# Altium schematic sheet CW.SchDoc(CW) (continued)

## Library data of the parts
C1 = C0805YC474KAT2A: CAP, X7R, 470NF, 16V, 0805, FULL REEL; Manufacturer: Kyocera AVX; Dissipation Factor: 3.5%; Insulation Resistance: 100GR; Tolerance: 10%; Capacitance: 470nF; Case Code (Imperial): 0805; Case Code (Metric): 2012; Case/Package: 0805; Category: Ceramic Capacitors; Component Kind: Standard; ComponentLink1Description: https://datasheet.ciiva.com/19476/2011221-19476183.pdf?src-supplier=Jameco; ComponentLink1URL: https://datasheet.ciiva.com/19476/2011221-19476183.pdf?src-supplier=Jameco; ComponentLink2Description: https://datasheet.ciiva.com/11786/0900766b80ed4be2-11786321.pdf?src-supplier=RSComponents; ComponentLink2URL: https://datasheet.ciiva.com/11786/0900766b80ed4be2-11786321.pdf?src-supplier=RSComponents; ComponentLink3Description: https://datasheet.ciiva.com/2988/cx7r-2988058.pdf?src-supplier=Avnet; ComponentLink3URL: https://datasheet.ciiva.com/2988/cx7r-2988058.pdf?src-supplier=Avnet; ComponentLink4Description: https://datasheet.ciiva.com/16326/cx7r-16326277.pdf?src-supplier=Future+Electronics; ComponentLink4URL: https://datasheet.ciiva.com/16326/cx7r-16326277.pdf?src-supplier=Future+Electronics; ComponentLink5Description: https://datasheet.ciiva.com/16623/cx7r-16623416.pdf?src-supplier=Avnet+Europe; ComponentLink5URL: https://datasheet.ciiva.com/16623/cx7r-16623416.pdf?src-supplier=Avnet+Europe; ComponentLink6Description: https://datasheet.ciiva.com/16544/cx7r-16544108.pdf?src-supplier=Avnet+Asia; ComponentLink6URL: https://datasheet.ciiva.com/16544/cx7r-16544108.pdf?src-supplier=Avnet+Asia; ComponentLink7Description: https://datasheet.ciiva.com/7812/cx7r-7812207.pdf?src-supplier=Element14; ComponentLink7URL: https://datasheet.ciiva.com/7812/cx7r-7812207.pdf?src-supplier=Element14; ComponentLink8Description: https://datasheet.ciiva.com/152/cx7r-152109.pdf?src-supplier=Digi-Key; ComponentLink8URL: https://datasheet.ciiva.com/152/cx7r-152109.pdf?src-supplier=Digi-Key; ComponentLink9Description: https://datasheet.ciiva.com/152/cx7r-152108.pdf?src-supplier=Digi-Key; ComponentLink9URL: https://datasheet.ciiva.com/152/cx7r-152108.pdf?src-supplier=Digi-Key; ComponentLink10Description: https://datasheet.ciiva.com/152/cx7r-152110.pdf?src-supplier=Digi-Key; ComponentLink10URL: https://datasheet.ciiva.com/152/cx7r-152110.pdf?src-supplier=Digi-Key; ComponentLink11Description: https://datasheet.ciiva.com/5416/cx7r-218113-5416693.pdf?src-supplier=Mouser; ComponentLink11URL: https://datasheet.ciiva.com/5416/cx7r-218113-5416693.pdf?src-supplier=Mouser; ComponentLink12Description: https://datasheet.ciiva.com/14590/70001075-14590213.pdf?src-supplier=Allied+Electronics; ComponentLink12URL: https://datasheet.ciiva.com/14590/70001075-14590213.pdf?src-supplier=Allied+Electronics; ComponentLink13Description: https://datasheet.ciiva.com/14602/70001075-14602165.pdf?src-supplier=Allied+Electronics; ComponentLink13URL: https://datasheet.ciiva.com/14602/70001075-14602165.pdf?src-supplier=Allied+Electronics; Construction: Flat; Contact Plating: Tin,Matte; Depth: 1.25mm; Dielectric: X7R; Height: 1.4mm; Lead Free: Lead Free; Length: 2.01mm; Manufacturer Part Number: 0805YC474KAT2A; Max Operating Temperature: 125°C; Min Operating Temperature: -55°C; Mount: Surface Mount,PCB; Number of Pins: 2; Package Quantity: 1; Packaging: Tape and Reel; Pricing 1: 1=0,27000, 10=0,18100, 50=0,10840, 100=0,09160, 500=0,06268, 1000=0,05544 (USD); Radiation Hardening: No; REACH SVHC: No SVHC; RoHS: Yes; RoHS Compliant: Yes; Series: -; Size: 1.4mm; Stock 1: 8545; Supplier 1: Digi-Key; Supplier Part Number 1: 478-1403-1-ND; Termination: SMD/SMT; Thickness: 0.055inch; Voltage: 16V; Voltage Rating: 16V; Voltage Rating (DC): 16V; Width: 1.25mm; Library Name: CW_Schlib.SchLib
C2-C4 C11 = C0805C104M4VACTU: CAP CER 0.1UF 16V 20% Y5V 0805; Manufacturer: KEMET; Capacitance: 100nF; Dielectric Material: Ceramic; Dissipation Factor: 7%; Case Code (Imperial): 0805; Case Code (Metric): 2012; Case/Package: 0805; Category: Ceramic Capacitors; Component Kind: Standard; ComponentLink1Description: http://www.mouser.com/ds/2/212/KEM_C1005_Y5V_SMD-1101305.pdf?src-supplier=Mouser; ComponentLink1URL: http://www.mouser.com/ds/2/212/KEM_C1005_Y5V_SMD-1101305.pdf?src-supplier=Mouser; ComponentLink2Description: https://api.kemet.com/component-edge/download/datasheet/C0805C104M4VACTU.pdf?src-supplier=Digi-Key; ComponentLink2URL: https://api.kemet.com/component-edge/download/datasheet/C0805C104M4VACTU.pdf?src-supplier=Digi-Key; Depth: 1.25mm; Dielectric: Y5V; Height: 0.9mm; Lead Free: Lead Free; Lead Pitch: 0.75mm; Length: 0.079inch; Manufacturer Part Number: C0805C104M4VACTU; Max Operating Temperature: 85°C; Min Operating Temperature: -30°C; Mount: Surface Mount; Package Quantity: 1; Packaging: Tape and Reel; Pricing 1: 1=0,13000, 10=0,09600, 50=0,05440, 100=0,04500, 500=0,03156, 1000=0,02613 (USD); RoHS: Yes; RoHS Compliant: Yes; Series: C; Stock 1: 9052; Supplier 1: Digi-Key; Supplier Part Number 1: 399-9156-1-ND; Termination: SMD/SMT; Thickness: 0.035inch; Tolerance: 20%; Voltage Rating: 16V; Voltage Rating (DC): 16V; Width: 0.049inch; Library Name: CW_Schlib.SchLib
C5 = CC0402KRX7R7BB103: CAP CER 10000PF 16V X7R 0402; Manufacturer: Yageo; Capacitance: 10nF; Dissipation Factor: 3.5%; Insulation Resistance: 10GR; Tolerance: 10%; Case Code (Imperial): 0402; Case Code (Metric): 1005; Case/Package: 0402; Category: Ceramic Capacitors; Component Kind: Standard; ComponentLink1Description: https://datasheet.ciiva.com/143/upy-gphc-x7r-6-3v-to-50v-8-143952.pdf?src-supplier=Digi-Key; ComponentLink1URL: https://datasheet.ciiva.com/143/upy-gphc-x7r-6-3v-to-50v-8-143952.pdf?src-supplier=Digi-Key; ComponentLink2Description: https://datasheet.ciiva.com/143/mlccorderingcode-143950.pdf?src-supplier=Digi-Key; ComponentLink2URL: https://datasheet.ciiva.com/143/mlccorderingcode-143950.pdf?src-supplier=Digi-Key; ComponentLink3Description: https://datasheet.ciiva.com/143/mlccorderingcode-143951.pdf?src-supplier=Digi-Key; ComponentLink3URL: https://datasheet.ciiva.com/143/mlccorderingcode-143951.pdf?src-supplier=Digi-Key; ComponentLink4Description: https://datasheet.ciiva.com/16625/upy-gphc-x7r-6-3v-to-50v-8-16625510.pdf?src-supplier=Avnet+Europe; ComponentLink4URL: https://datasheet.ciiva.com/16625/upy-gphc-x7r-6-3v-to-50v-8-16625510.pdf?src-supplier=Avnet+Europe; ComponentLink5Description: https://datasheet.ciiva.com/8033/70765-8033655.pdf?src-supplier=Element14; ComponentLink5URL: https://datasheet.ciiva.com/8033/70765-8033655.pdf?src-supplier=Element14; ComponentLink6Description: https://datasheet.ciiva.com/8033/1489800-8033658.pdf?src-supplier=Element14; ComponentLink6URL: https://datasheet.ciiva.com/8033/1489800-8033658.pdf?src-supplier=Element14; ComponentLink7Description: https://datasheet.ciiva.com/28450/1489800-28450330.pdf?src-supplier=Element14; ComponentLink7URL: https://datasheet.ciiva.com/28450/1489800-28450330.pdf?src-supplier=Element14; ComponentLink8Description: https://datasheet.ciiva.com/8033/1489800-8033659.pdf?src-supplier=Element14; ComponentLink8URL: https://datasheet.ciiva.com/8033/1489800-8033659.pdf?src-supplier=Element14; ComponentLink9Description: https://datasheet.ciiva.com/7978/1489800-7978109.pdf?src-supplier=Element14; ComponentLink9URL: https://datasheet.ciiva.com/7978/1489800-7978109.pdf?src-supplier=Element14; ComponentLink10Description: https://datasheet.ciiva.com/5425/upy-gp-np0-16v-to-50v-8-3714-5425438.pdf?src-supplier=Mouser; ComponentLink10URL: https://datasheet.ciiva.com/5425/upy-gp-np0-16v-to-50v-8-3714-5425438.pdf?src-supplier=Mouser; Depth: 0.5mm; Dielectric: X7R; Halogen Free: Halogen Free; Height: 0.5mm; Lead Free: Lead Free; Length: 1mm; Manufacturer Part Number: CC0402KRX7R7BB103; Max Operating Temperature: 125°C; Min Operating Temperature: -55°C; Mount: Surface Mount; Number of Pins: 2; Package Quantity: 1; Packaging: Tape and Reel; Pricing 1: 1=0,00300, 500=0,00220, 1500=0,00210, 2500=0,00200, 5000=0,00200 (USD); Radiation Hardening: No; REACH SVHC: No SVHC; RoHS: Yes; RoHS Compliant: Yes; Series: CC; Stock 1: 39464018; Supplier 1: Future Electronics; Supplier Part Number 1: 9410754; Termination: SMD/SMT; Thickness: 0.022inch; Voltage: 16V; Voltage Rating: 16V; Voltage Rating (DC): 16V; Width: 0.02inch; Library Name: CW_Schlib.SchLib
C6 = EEEFK1C471P: PANASONIC - EEEFK1C471P - SMD Aluminum Electrolytic Capacitor, Radial Can - SMD, 470 µF, 16 V, FK Series, 2000 hours @ 105°C; Dielectric Material: Aluminium; ESR (Equivalent Series Resistance): 160mR; Leakage Current: 3uA; Ripple Current: 600mA; Tolerance: 20%; Voltage: 16V; Capacitance: 470uF; Case/Package: Radial; Category: Aluminum Electrolytic Capacitors; Component Kind: Standard; ComponentLink1Description: https://datasheet.ciiva.com/11777/0900766b80e4e8b0-11777355.pdf?src-supplier=RSComponents; ComponentLink1URL: https://datasheet.ciiva.com/11777/0900766b80e4e8b0-11777355.pdf?src-supplier=RSComponents; ComponentLink2Description: https://datasheet.ciiva.com/29313/443466-da-01-en-smd-elko-vfk-f-470-uf-16v-29313494.pdf?src-supplier=Conrad; ComponentLink2URL: https://datasheet.ciiva.com/29313/443466-da-01-en-smd-elko-vfk-f-470-uf-16v-29313494.pdf?src-supplier=Conrad; ComponentLink3Description: https://datasheet.ciiva.com/15165/getdatasheetpartid-85020-15165862.pdf?src-supplier=Verical; ComponentLink3URL: https://datasheet.ciiva.com/15165/getdatasheetpartid-85020-15165862.pdf?src-supplier=Verical; ComponentLink4Description: https://datasheet.ciiva.com/2983/aba0000ce120-2983946.pdf?src-supplier=Avnet; ComponentLink4URL: https://datasheet.ciiva.com/2983/aba0000ce120-2983946.pdf?src-supplier=Avnet; ComponentLink5Description: https://datasheet.ciiva.com/14592/70258115-14592955.pdf?src-supplier=Allied+Electronics; ComponentLink5URL: https://datasheet.ciiva.com/14592/70258115-14592955.pdf?src-supplier=Allied+Electronics; ComponentLink6Description: https://datasheet.ciiva.com/6121/1676921-6121148.pdf?src-supplier=Farnell; ComponentLink6URL: https://datasheet.ciiva.com/6121/1676921-6121148.pdf?src-supplier=Farnell; ComponentLink7Description: https://datasheet.ciiva.com/14595/70258115-14595868.pdf?src-supplier=Allied+Electronics; ComponentLink7URL: https://datasheet.ciiva.com/14595/70258115-14595868.pdf?src-supplier=Allied+Electronics; ComponentLink8Description: https://datasheet.ciiva.com/30213/aba0000ce120-30213244.pdf?src-supplier=Onlinecomponents.com; ComponentLink8URL: https://datasheet.ciiva.com/30213/aba0000ce120-30213244.pdf?src-supplier=Onlinecomponents.com; ComponentLink9Description: https://datasheet.ciiva.com/46376/1676921-46376492.pdf?src-supplier=Element14; ComponentLink9URL: https://datasheet.ciiva.com/46376/1676921-46376492.pdf?src-supplier=Element14; ComponentLink10Description: https://datasheet.ciiva.com/2985/aba0000ce120-2985405.pdf?src-supplier=Avnet; ComponentLink10URL: https://datasheet.ciiva.com/2985/aba0000ce120-2985405.pdf?src-supplier=Avnet; ComponentLink11Description: https://datasheet.ciiva.com/25899/aba0000ce120-25899342.pdf?src-supplier=Avnet+Europe; ComponentLink11URL: https://datasheet.ciiva.com/25899/aba0000ce120-25899342.pdf?src-supplier=Avnet+Europe; Construction: Cylindrical; Contact Plating: Tin; Diameter: 8mm; Height: 10.2mm; Height - Seated (Max): 0.402inch; Impedance: 160mR; Lead Free: Lead Free; Length: 10.2mm; Life (Hours): 2000Hour; Manufacturer: Panasonic; Manufacturer Part Number: EEEFK1C471P; Material: Aluminium; Max Operating Temperature: 105°C; Min Operating Temperature: -55°C; Mount: Surface Mount,PCB; Number of Pins: 2; Package Quantity: 1; Packaging: Tape and Reel; Pricing 1: 50=0,38600, 150=0,32900 (USD); Radiation Hardening: No; REACH SVHC: No SVHC; Ripple Current (AC): 600mA; RoHS: Yes; RoHS Compliant: Yes; Series: FK; Stock 1: 30580; Supplier 1: RSComponents; Supplier Part Number 1: 5370477P; Termination: SMD/SMT; Voltage Rating: 16V; Voltage Rating (DC): 16V; Width: 10mm; Library Name: CW_Schlib.SchLib
C7 C10 = C1206C220J5GACTU: 1206 22pF Ceramic Multilayer Capacitor; 50VDC; 125degC; C0G Dielec; +/-5% SMD; Manufacturer: KEMET; Dissipation Factor: 0.1%; Temperature Coefficient: 30ppm/°C; Tolerance: 5%; Voltage: 50V; Capacitance: 22pF; Case Code (Imperial): 1206; Case Code (Metric): 3216; Case/Package: 1206; Category: Ceramic Capacitors; Component Kind: Standard; ComponentLink1Description: https://datasheet.ciiva.com/6177/1776099-6177664.pdf?src-supplier=Farnell; ComponentLink1URL: https://datasheet.ciiva.com/6177/1776099-6177664.pdf?src-supplier=Farnell; ComponentLink2Description: https://datasheet.ciiva.com/145/docfinderpartnumber-c1206c220j5gactu-145591.pdf?src-supplier=Digi-Key; ComponentLink2URL: https://datasheet.ciiva.com/145/docfinderpartnumber-c1206c220j5gactu-145591.pdf?src-supplier=Digi-Key; ComponentLink3Description: https://datasheet.ciiva.com/7729/1776099-7729850.pdf?src-supplier=Element14; ComponentLink3URL: https://datasheet.ciiva.com/7729/1776099-7729850.pdf?src-supplier=Element14; ComponentLink4Description: https://datasheet.ciiva.com/28668/1789732-28668845.pdf?src-supplier=Element14; ComponentLink4URL: https://datasheet.ciiva.com/28668/1789732-28668845.pdf?src-supplier=Element14; ComponentLink5Description: https://datasheet.ciiva.com/14593/70095383-14593639.pdf?src-supplier=Allied+Electronics; ComponentLink5URL: https://datasheet.ciiva.com/14593/70095383-14593639.pdf?src-supplier=Allied+Electronics; ComponentLink6Description: https://datasheet.ciiva.com/14608/70095383-14608661.pdf?src-supplier=Allied+Electronics; ComponentLink6URL: https://datasheet.ciiva.com/14608/70095383-14608661.pdf?src-supplier=Allied+Electronics; ComponentLink7Description: https://datasheet.ciiva.com/2988/kem-c1003-c0g-smd-2988752.pdf?src-supplier=Avnet; ComponentLink7URL: https://datasheet.ciiva.com/2988/kem-c1003-c0g-smd-2988752.pdf?src-supplier=Avnet; ComponentLink8Description: https://datasheet.ciiva.com/2988/kem-c1003-c0g-smd-2988751.pdf?src-supplier=Avnet; ComponentLink8URL: https://datasheet.ciiva.com/2988/kem-c1003-c0g-smd-2988751.pdf?src-supplier=Avnet; ComponentLink9Description: https://datasheet.ciiva.com/18102/getdatasheetpartid-68144-18102364.pdf?src-supplier=Verical; ComponentLink9URL: https://datasheet.ciiva.com/18102/getdatasheetpartid-68144-18102364.pdf?src-supplier=Verical; ComponentLink10Description: https://datasheet.ciiva.com/11649/kem-c1003-c0g-smd-11649994.pdf?src-supplier=Avnet; ComponentLink10URL: https://datasheet.ciiva.com/11649/kem-c1003-c0g-smd-11649994.pdf?src-supplier=Avnet; Construction: Flat; Contact Material: Tin; Depth: 1.6mm; Dielectric: C0G; Dielectric Material: Ceramic; Height: 0.78mm; Lead Free: Lead Free; Length: 3.2mm; Manufacturer Part Number: C1206C220J5GACTU; Max Operating Temperature: 125°C; Min Operating Temperature: -55°C; Mount: Surface Mount,PCB; Package Quantity: 1; Packaging: Tape and Reel; Pricing 1: 1=0,25000, 10=0,16700, 50=0,10040, 100=0,08480, 500=0,05800, 1000=0,05131 (USD); Radiation Hardening: No; REACH SVHC: No SVHC; RoHS: Yes; RoHS Compliant: Yes; Series: C; Stock 1: 60049; Supplier 1: Digi-Key; Supplier Part Number 1: 399-1197-1-ND; Termination: SMD/SMT; Thickness: 0.035inch; Voltage Rating: 50V; Voltage Rating (DC): 50V; Width: 1.6mm; Library Name: CW_Schlib.SchLib
C8 = C0805C273K5RACTU: CAP CER 0.027UF 50V X7R 0805; Manufacturer: KEMET; Capacitance: 27nF; Dielectric Material: Ceramic; Dissipation Factor: 2.5%; Tolerance: 10%; Case Code (Imperial): 0805; Case Code (Metric): 2012; Case/Package: 0805; Category: Ceramic Capacitors; Component Kind: Standard; ComponentLink1Description: https://datasheet.ciiva.com/14593/70095383-14593543.pdf?src-supplier=Allied+Electronics; ComponentLink1URL: https://datasheet.ciiva.com/14593/70095383-14593543.pdf?src-supplier=Allied+Electronics; ComponentLink2Description: https://datasheet.ciiva.com/14592/70095383-14592123.pdf?src-supplier=Allied+Electronics; ComponentLink2URL: https://datasheet.ciiva.com/14592/70095383-14592123.pdf?src-supplier=Allied+Electronics; ComponentLink3Description: https://datasheet.ciiva.com/7842/1918693-7842589.pdf?src-supplier=Element14; ComponentLink3URL: https://datasheet.ciiva.com/7842/1918693-7842589.pdf?src-supplier=Element14; ComponentLink4Description: https://datasheet.ciiva.com/7842/kem-c1002-x7r-smd-7842590.pdf?src-supplier=Element14; ComponentLink4URL: https://datasheet.ciiva.com/7842/kem-c1002-x7r-smd-7842590.pdf?src-supplier=Element14; Construction: Flat; Depth: 1.25mm; Dielectric: X7R; Height: 0.78mm; Lead Pitch: 0.75mm; Length: 2mm; Manufacturer Part Number: C0805C273K5RACTU; Max Operating Temperature: 125°C; Min Operating Temperature: -55°C; Mount: Surface Mount,PCB; Number of Pins: 2; Package Quantity: 1; Packaging: Tape and Reel; Pricing 1: 1=0,11000, 10=0,08100, 50=0,04580, 100=0,03800, 500=0,02666, 1000=0,02207 (USD); Radiation Hardening: No; REACH SVHC: No SVHC; RoHS: Yes; RoHS Compliant: Yes; Series: C; Stock 1: 100815; Supplier 1: Digi-Key; Supplier Part Number 1: 399-1164-1-ND; Termination: SMD/SMT; Thickness: 0.035inch; Voltage: 50V; Voltage Rating: 50V; Voltage Rating (DC): 50V; Width: 0.049inch; Library Name: CW_Schlib.SchLib
C9 = C08051C152KAT2A: 0805 1.5nF Ceramic Multilayer Capacitor,  100 VDC,  +125degC,  X7R Dielec,  +/-10%; Manufacturer: Kyocera AVX; Dissipation Factor: 2.5%; Insulation Resistance: 100GR; Tolerance: 10%; Voltage: 100V; Capacitance: 1.5nF; Case Code (Imperial): 0805; Case Code (Metric): 2012; Case/Package: 0805; Category: Ceramic Capacitors; Component Kind: Standard; ComponentLink1Description: https://datasheet.ciiva.com/150/cx7r-150629.pdf?src-supplier=Digi-Key; ComponentLink1URL: https://datasheet.ciiva.com/150/cx7r-150629.pdf?src-supplier=Digi-Key; ComponentLink2Description: https://datasheet.ciiva.com/150/cx7r-150630.pdf?src-supplier=Digi-Key; ComponentLink2URL: https://datasheet.ciiva.com/150/cx7r-150630.pdf?src-supplier=Digi-Key; ComponentLink3Description: https://datasheet.ciiva.com/150/cx7r-150631.pdf?src-supplier=Digi-Key; ComponentLink3URL: https://datasheet.ciiva.com/150/cx7r-150631.pdf?src-supplier=Digi-Key; ComponentLink4Description: https://datasheet.ciiva.com/7811/cx7r-7811928.pdf?src-supplier=Element14; ComponentLink4URL: https://datasheet.ciiva.com/7811/cx7r-7811928.pdf?src-supplier=Element14; ComponentLink5Description: https://datasheet.ciiva.com/7811/cx7r-7811929.pdf?src-supplier=Element14; ComponentLink5URL: https://datasheet.ciiva.com/7811/cx7r-7811929.pdf?src-supplier=Element14; ComponentLink6Description: https://datasheet.ciiva.com/7811/cx7r-7811930.pdf?src-supplier=Element14; ComponentLink6URL: https://datasheet.ciiva.com/7811/cx7r-7811930.pdf?src-supplier=Element14; Contact Plating: Tin,Matte; Depth: 1.25mm; Dielectric: X7R; Dielectric Material: Ceramic; Height: 0.94mm; Lead Free: Lead Free; Length: 2.01mm; Manufacturer Part Number: 08051C152KAT2A; Max Operating Temperature: 125°C; Min Operating Temperature: -55°C; Mount: Surface Mount,PCB; Number of Pins: 2; Package Quantity: 1; Packaging: Tape and Reel; Pricing 1: 1=0,19000, 10=0,13200, 50=0,07480, 100=0,06200, 500=0,04350, 1000=0,03601 (USD); Radiation Hardening: No; REACH SVHC: No SVHC; RoHS: Yes; RoHS Compliant: Yes; Stock 1: 214; Supplier 1: Digi-Key; Supplier Part Number 1: 478-1353-1-ND; Termination: SMD/SMT; Thickness: 0.037inch; Voltage Rating: 100V; Voltage Rating (DC): 100V; Width: 0.049inch; Library Name: CW_Schlib.SchLib
Cr1 = ATS16ASM-1: CRYSTAL 16.0MHZ 20PF SMD; Manufacturer: CTS; ESR (Equivalent Series Resistance): 40R; Frequency Stability: 50ppm; Frequency Tolerance: 30ppm; Category: Crystals; Component Kind: Standard; ComponentLink1Description: https://datasheet.ciiva.com/861/008-0309-0-861005.pdf?src-supplier=Digi-Key; ComponentLink1URL: https://datasheet.ciiva.com/861/008-0309-0-861005.pdf?src-supplier=Digi-Key; Frequency: 16MHz; Height: 0.169inch; Length: 0.437inch; Load Capacitance: 20pF; Manufacturer Part Number: ATS16ASM-1; Max Operating Temperature: 70°C; Min Operating Temperature: -20°C; Mount: Surface Mount; Number of Pins: 2; Package Quantity: 1000; Packaging: Tape and Reel; Pricing 1: 1=0,37000, 10=0,30500, 50=0,27440, 100=0,24400, 500=0,23180 (USD); RoHS: Yes; RoHS Compliant: Yes; Series: ATS-SM; Series Resistance: 40R; Stock 1: 949; Supplier 1: Digi-Key; Supplier Part Number 1: CTX1440CT-ND; Termination: SMD/SMT; Tolerance: 30ppm; Width: 0.19inch; Library Name: CW_Schlib.SchLib
DA1 = LM358N/NOPB (1): TEXAS INSTRUMENTS   LM358N/NOPB..   OP AMP, 1MHZ, 0.1V/S, 7MV, DIP8; Manufacturer: TI National Semiconductor; Common Mode Rejection Ratio: 65dB; Input Bias Current: 250nA; Max Dual Supply Voltage: 16V; Max Power Dissipation: 830mW; Max Supply Current: 1.2mA; Max Supply Voltage: 32V; Min Dual Supply Voltage: 1.5V; Min Supply Voltage: 3V; Nominal Supply Current: 500uA; Number of Amplifiers: 2; Operating Supply Current: 1mA; Output Current: 30mA; Output Current per Channel: 40mA; Slew Rate: 0.1V/µs; Voltage Gain: 100dB; Bandwidth: 1MHz; Case/Package: PDIP; Category: Amplifiers - Op Amps, Buffer, Instrumentation; Component Kind: Standard; Gain Bandwidth Product: 1MHz; Height: 3.3mm; Input Offset Voltage (Vos): 7mV; Lead Free: Lead Free; Length: 9.27mm; Manufacturer Part Number: LM358N/NOPB; Max Operating Temperature: 70°C; Min Operating Temperature: 0°C; Mount: Through Hole; Number of Channels: 2; Number of Circuits: 2; Number of Elements: 2; Number of Pins: 8; Operating Supply Voltage: 16V; Package Quantity: 40; Packaging: Rail/Tube; Power Dissipation: 830mW; Power Supply Rejection Ratio (PSRR): 65dB; Pricing 1: 1=1,00000, 10=0,89100, 25=0,84600, 100=0,69480, 250=0,64952, 500=0,57400, 1000=0,47595 (USD); Radiation Hardening: No; REACH SVHC: No SVHC; RoHS: Yes; Stock 1: 24976; Supplier 1: Digi-Key; Supplier Part Number 1: LM358NNS/NOPB-ND; Unity Gain Bandwidth Product: 1MHz; Weight: 0.01lb; Width: 6.35mm; Library Name: CW_Schlib.SchLib
DA2 = LM7805CT/NOPB: Series 3-Terminal Positive Regulators; Manufacturer: Texas Instruments; Accuracy: 2%; Dropout Voltage: 2V; Max Input Voltage: 35V; Max Output Current: 1A; Min Input Voltage: 10V; Number of Outputs: 1; Output Type: Fixed; Quiescent Current: 8mA; Case/Package: TO-220; Category: Voltage Regulators - Linear; Component Kind: Standard; ComponentLink1Description: https://datasheet.ciiva.com/45987/902071-45987836.pdf?src-supplier=Jameco; ComponentLink1URL: https://datasheet.ciiva.com/45987/902071-45987836.pdf?src-supplier=Jameco; ComponentLink2Description: https://datasheet.ciiva.com/15083/lm7805c-15083790.pdf?src-supplier=Digi-Key; ComponentLink2URL: https://datasheet.ciiva.com/15083/lm7805c-15083790.pdf?src-supplier=Digi-Key; ComponentLink3Description: https://datasheet.ciiva.com/14280/lm340-n-14280911.pdf?src-supplier=Avnet; ComponentLink3URL: https://datasheet.ciiva.com/14280/lm340-n-14280911.pdf?src-supplier=Avnet; Height: 8.89mm; Lead Free: Contains Lead; Length: 10.16mm; Manufacturer Part Number: LM7805CT/NOPB; Max Operating Temperature: 125°C; Min Operating Temperature: 0°C; Mount: Through Hole; Number of Pins: 3; Number of Regulators: 1; Output Current: 1A; Output Voltage: 5V; Output Voltage Accuracy: 2%; Package Quantity: 45; Packaging: Rail/Tube; Polarity: Positive; Pricing 1: 1=1,63000, 10=1,46300, 25=1,38080, 100=1,17630, 250=1,10452, 500=0,96646, 1000=0,87007 (USD); Radiation Hardening: No; RoHS: Yes; RoHS Compliant: Yes; Series: -; Stock 1: 13155; Supplier 1: Digi-Key; Supplier Part Number 1: 296-47192-ND; Width: 4.7mm; Library Name: CW_Schlib.SchLib
HL1 HL3 = 151051RS11000: WURTH ELEKTRONIK - 151051RS11000 - LED, Red, Through Hole, T-1 3/4 (5mm), 30 mA, 2.1 V, 630 nm; Manufacturer: Wurth Electronics; Dominant Wavelength: 645nm; Forward Current: 30mA; Forward Voltage: 2.1V; Lens Style: Diffused,Round,Circular; Luminous Intensity: 30mcd; Test Current: 20mA; Viewing Angle: 60°; Wavelength: 630nm; Case/Package: Radial; Category: LEDs; Color: Red; Component Kind: Standard; ComponentLink1Description: https://datasheet.ciiva.com/23843/151051rs11000-23843281.pdf?src-supplier=Newark; ComponentLink1URL: https://datasheet.ciiva.com/23843/151051rs11000-23843281.pdf?src-supplier=Newark; ComponentLink2Description: https://datasheet.ciiva.com/29335/759488-da-01-en-tht-led-5mm-rot-151051rs11000-29335455.pdf?src-supplier=Conrad; ComponentLink2URL: https://datasheet.ciiva.com/29335/759488-da-01-en-tht-led-5mm-rot-151051rs11000-29335455.pdf?src-supplier=Conrad; ComponentLink3Description: https://datasheet.ciiva.com/22657/1911506-22657034.pdf?src-supplier=Element14; ComponentLink3URL: https://datasheet.ciiva.com/22657/1911506-22657034.pdf?src-supplier=Element14; ComponentLink4Description: https://datasheet.ciiva.com/29562/151051rs11000-29562906.pdf?src-supplier=Digi-Key; ComponentLink4URL: https://datasheet.ciiva.com/29562/151051rs11000-29562906.pdf?src-supplier=Digi-Key; Height: 8.9mm; Lens Size: 5mm; Manufacturer Part Number: 151051RS11000; Max Operating Temperature: 85°C; Min Operating Temperature: -40°C; Mount: Through Hole; Package Quantity: 1; Pricing 1: 1=0,16000, 50=0,14500, 100=0,12500, 500=0,12000 (USD); REACH SVHC: Unknown; RoHS: Yes; RoHS Compliant: Yes; Stock 1: 6443; Supplier 1: Digi-Key; Supplier Part Number 1: 732-5016-ND; Library Name: CW_Schlib.SchLib
HL2 = 151051BS04000: WURTH ELEKTRONIK - 151051BS04000 - LED, 5MM, BLUE, 500MCD, 470NM; Manufacturer: Wurth Electronics; Forward Current: 30mA; Forward Voltage: 3.2V; Lens Style: Diffused,Circular,Round; Test Current: 20mA; Viewing Angle: 40°; Case/Package: Radial; Category: LEDs; Color: Blue; Component Kind: Standard; ComponentLink1Description: https://datasheet.ciiva.com/23843/151051bs04000-23843280.pdf?src-supplier=Newark; ComponentLink1URL: https://datasheet.ciiva.com/23843/151051bs04000-23843280.pdf?src-supplier=Newark; ComponentLink2Description: https://datasheet.ciiva.com/29363/759492-da-01-en-tht-led-5mm-blau-151051bs04000-29363825.pdf?src-supplier=Conrad; ComponentLink2URL: https://datasheet.ciiva.com/29363/759492-da-01-en-tht-led-5mm-blau-151051bs04000-29363825.pdf?src-supplier=Conrad; ComponentLink3Description: https://datasheet.ciiva.com/29562/151051bs04000-29562902.pdf?src-supplier=Digi-Key; ComponentLink3URL: https://datasheet.ciiva.com/29562/151051bs04000-29562902.pdf?src-supplier=Digi-Key; Dominant Wavelength: 470nm; Height: 8.9mm; Lens Size: 5mm; Luminous Intensity: 500mcd; Manufacturer Part Number: 151051BS04000; Max Operating Temperature: 85°C; Min Operating Temperature: -40°C; Mount: Through Hole; Package Quantity: 1; Packaging: Bulk; Pricing 1: 1=0,25000, 50=0,23000, 100=0,19800, 500=0,18600 (USD); REACH SVHC: Unknown; RoHS: Yes; RoHS Compliant: Yes; Stock 1: 14295; Supplier 1: Digi-Key; Supplier Part Number 1: 732-5015-ND; Wavelength: 470nm; Library Name: CW_Schlib.SchLib
HL4 HL6 = 151051VS04000: WURTH ELEKTRONIK - 151051VS04000 - LED, Green, Through Hole, T-1 3/4 (5mm), 30 mA, 2.1 V, 572 nm; Manufacturer: Wurth Electronics; Dominant Wavelength: 572nm; Forward Current: 30mA; Forward Voltage: 2.1V; Lens Style: Diffused,Circular,Round; Luminous Intensity: 140mcd; Test Current: 20mA; Viewing Angle: 40°; Case/Package: Radial; Category: LEDs; Color: Green; Component Kind: Standard; ComponentLink1Description: https://datasheet.ciiva.com/23843/151051vs04000-23843282.pdf?src-supplier=Newark; ComponentLink1URL: https://datasheet.ciiva.com/23843/151051vs04000-23843282.pdf?src-supplier=Newark; ComponentLink2Description: https://datasheet.ciiva.com/29363/759491-da-01-en-tht-led-5mm-b-gruen-151051vs04000-29363828.pdf?src-supplier=Conrad; ComponentLink2URL: https://datasheet.ciiva.com/29363/759491-da-01-en-tht-led-5mm-b-gruen-151051vs04000-29363828.pdf?src-supplier=Conrad; ComponentLink3Description: https://datasheet.ciiva.com/29562/151051vs04000-29562903.pdf?src-supplier=Digi-Key; ComponentLink3URL: https://datasheet.ciiva.com/29562/151051vs04000-29562903.pdf?src-supplier=Digi-Key; ComponentLink4Description: https://datasheet.ciiva.com/48429/151051vs04000-eng-tds-48429978.pdf?src-supplier=Distrelec; ComponentLink4URL: https://datasheet.ciiva.com/48429/151051vs04000-eng-tds-48429978.pdf?src-supplier=Distrelec; Height: 8.9mm; Lens Size: 5mm; Manufacturer Part Number: 151051VS04000; Max Operating Temperature: 85°C; Min Operating Temperature: -40°C; Mount: Through Hole; Package Quantity: 1; Pricing 1: 1=0,25000, 50=0,23000, 100=0,19800, 500=0,18600 (USD); REACH SVHC: Unknown; RoHS: Yes; RoHS Compliant: Yes; Stock 1: 5536; Supplier 1: Digi-Key; Supplier Part Number 1: 732-5017-ND; Wavelength: 572nm; Library Name: CW_Schlib.SchLib
HL5 = 151051YS04000: WURTH ELEKTRONIK - 151051YS04000 - LED, Yellow, Through Hole, T-1 3/4 (5mm), 30 mA, 2 V, 590 nm; Manufacturer: Wurth Electronics; Dominant Wavelength: 590nm; Forward Current: 30mA; Forward Voltage: 2V; Lens Style: Diffused,Round,Circular; Luminous Intensity: 450mcd; Test Current: 20mA; Viewing Angle: 40°; Case/Package: Radial; Category: LEDs; Color: Yellow; Component Kind: Standard; ComponentLink1Description: https://datasheet.ciiva.com/29562/151051ys04000-29562897.pdf?src-supplier=Digi-Key; ComponentLink1URL: https://datasheet.ciiva.com/29562/151051ys04000-29562897.pdf?src-supplier=Digi-Key; ComponentLink2Description: https://datasheet.ciiva.com/29335/759489-da-01-en-tht-led-5mm-gelb-151051ys04000-29335459.pdf?src-supplier=Conrad; ComponentLink2URL: https://datasheet.ciiva.com/29335/759489-da-01-en-tht-led-5mm-gelb-151051ys04000-29335459.pdf?src-supplier=Conrad; ComponentLink3Description: https://datasheet.ciiva.com/48429/151051ys04000-eng-tds-48429979.pdf?src-supplier=Distrelec; ComponentLink3URL: https://datasheet.ciiva.com/48429/151051ys04000-eng-tds-48429979.pdf?src-supplier=Distrelec; Height: 8.9mm; Lens Size: 5mm; Manufacturer Part Number: 151051YS04000; Max Operating Temperature: 85°C; Min Operating Temperature: -40°C; Mount: Through Hole; Package Quantity: 1; Pricing 1: 1=0,21000, 50=0,19800, 100=0,17100, 500=0,16050 (USD); REACH SVHC: Unknown; RoHS: Yes; RoHS Compliant: Yes; Stock 1: 1858; Supplier 1: Digi-Key; Supplier Part Number 1: 732-5018-ND; Wavelength: 590nm; Library Name: CW_Schlib.SchLib
J1 = ATMEGA8A-PU: MCU 8-bit ATmega AVR RISC 8KB Flash 3.3V/5V 28-Pin PDIP; Manufacturer: Microchip / Atmel; Data Bus Width: 8b; Frequency: 16MHz; Interface: SPI,USART,I2C,UART; Max Supply Voltage: 5.5V; Min Supply Voltage: 2.7V; Number of ADC Channels: 6; Number of I2C Channels: 1; Number of I/Os: 23; Number of PWM Channels: 3; Oscillator Type: Internal; Peripherals: Brown-out Detect/Reset,POR,PWM,WDT; RAM Size: 1KB; Watchdog Timer: Yes; Case/Package: PDIP; Category: Microcontrollers; Component Kind: Standard; ComponentLink1Description: https://datasheet.ciiva.com/15213/getdatasheetpartid-316011-15213203.pdf?src-supplier=Verical; ComponentLink1URL: https://datasheet.ciiva.com/15213/getdatasheetpartid-316011-15213203.pdf?src-supplier=Verical; ComponentLink2Description: https://datasheet.ciiva.com/26818/atmega8a-pu-26818556.pdf?src-supplier=IIIC; ComponentLink2URL: https://datasheet.ciiva.com/26818/atmega8a-pu-26818556.pdf?src-supplier=IIIC; ComponentLink3Description: https://datasheet.ciiva.com/24154/atmel-8159-8-bit-avr-microcontroller-atmega8a-summary-24154172.pdf?src-supplier=Future+Electronics; ComponentLink3URL: https://datasheet.ciiva.com/24154/atmel-8159-8-bit-avr-microcontroller-atmega8a-summary-24154172.pdf?src-supplier=Future+Electronics; ComponentLink4Description: https://datasheet.ciiva.com/6658/8159s-6658029.pdf?src-supplier=Digi-Key; ComponentLink4URL: https://datasheet.ciiva.com/6658/8159s-6658029.pdf?src-supplier=Digi-Key; Contact Plating: Tin,Matte; Core Architecture: AVR; Height: 4.572mm; Lead Free: Lead Free; Length: 34.8mm; Manufacturer Part Number: ATMEGA8A-PU; Max Frequency: 16MHz; Max Operating Temperature: 85°C; Memory Size: 8KB; Memory Type: FLASH; Min Operating Temperature: -40°C; Mount: Through Hole; Number of Pins: 28; Number of Programmable I/O: 23; Number of SPI Channels: 1; Number of Timers/Counters: 3; Package Quantity: 14; Packaging: Rail/Tube; Pricing 1: 1=2,66000, 25=2,43120, 100=2,20000 (USD); Radiation Hardening: No; REACH SVHC: No SVHC; RoHS: Yes; RoHS Compliant: Yes; Series: AVR® ATmega; Stock 1: 7653; Supplier 1: Digi-Key; Supplier Part Number 1: ATMEGA8A-PU-ND; Width: 7.49mm; Library Name: CW_Schlib.SchLib
R1 R11 = CRCW080510K0JNEAC: Res Thick Film 0805 10K Ohm 5% 1/8W ±200ppm/°C Molded SMD Paper T/R; Manufacturer: Vishay Semiconductors; Power Rating: 125mW; Case/Package: SMD/SMT; Category: Resistors; Component Kind: Standard; ComponentLink1Description: http://www.vishay.com/docs/20035/dcrcwe3.pdf?src-supplier=Avnet+Asia; ComponentLink1URL: http://www.vishay.com/docs/20035/dcrcwe3.pdf?src-supplier=Avnet+Asia; ComponentLink2Description: http://www.vishay.com/docs/28773/crcwce3.pdf?src-supplier=Digi-Key; ComponentLink2URL: http://www.vishay.com/docs/28773/crcwce3.pdf?src-supplier=Digi-Key; Contact Plating: Tin; Lead Free: Lead Free; Length: 2mm; Library Name: CW_Schlib.SchLib; Manufacturer Part Number: CRCW080510K0JNEAC; Max Operating Temperature: 155°C; Min Operating Temperature: -55°C; Mount: Surface Mount; Pricing 1: 1=0,10000, 10=0,04000, 100=0,01630, 1000=0,00731, 2500=0,00635 (USD); Resistance: 10kR; Resistor Type: Thick Film; RoHS: Yes; RoHS Compliant: Yes; Stock 1: 146; Supplier 1: Digi-Key; Supplier Part Number 1: 541-4163-1-ND; Temperature Coefficient: 200ppm/°C; Termination: Wraparound; Tolerance: 5%
R2 R3 R13-R18 = CRCW08051K00JNEA: Res Thick Film 0805 1K Ohm 5% 1/8W 200ppm/C Molded SMD SMD Paper T/R; Manufacturer: Vishay Dale; Max Power Dissipation: 125mW; Temperature Coefficient: 200ppm/°C; Voltage Rating: 150V; Case Code (Imperial): 0805; Case Code (Metric): 2012; Case/Package: 0805; Category: Chip SMD Resistors; Component Kind: Standard; ComponentLink1Description: https://datasheet.ciiva.com/8337/dcrcwe3-8337669.pdf?src-supplier=Avnet; ComponentLink1URL: https://datasheet.ciiva.com/8337/dcrcwe3-8337669.pdf?src-supplier=Avnet; ComponentLink2Description: https://datasheet.ciiva.com/5544/dcrcwe3-109170-5544649.pdf?src-supplier=Mouser; ComponentLink2URL: https://datasheet.ciiva.com/5544/dcrcwe3-109170-5544649.pdf?src-supplier=Mouser; ComponentLink3Description: https://datasheet.ciiva.com/2463/dcrcwe3-2463178.pdf?src-supplier=Digi-Key; ComponentLink3URL: https://datasheet.ciiva.com/2463/dcrcwe3-2463178.pdf?src-supplier=Digi-Key; ComponentLink4Description: https://datasheet.ciiva.com/2463/dcrcwe3-2463179.pdf?src-supplier=Digi-Key; ComponentLink4URL: https://datasheet.ciiva.com/2463/dcrcwe3-2463179.pdf?src-supplier=Digi-Key; ComponentLink5Description: https://datasheet.ciiva.com/46441/1533075-46441628.pdf?src-supplier=Element14; ComponentLink5URL: https://datasheet.ciiva.com/46441/1533075-46441628.pdf?src-supplier=Element14; ComponentLink6Description: https://datasheet.ciiva.com/3258/dcrcwe3-3258221.pdf?src-supplier=Avnet; ComponentLink6URL: https://datasheet.ciiva.com/3258/dcrcwe3-3258221.pdf?src-supplier=Avnet; ComponentLink7Description: https://datasheet.ciiva.com/16755/70201119-16755757.pdf?src-supplier=Allied+Electronics; ComponentLink7URL: https://datasheet.ciiva.com/16755/70201119-16755757.pdf?src-supplier=Allied+Electronics; ComponentLink8Description: https://datasheet.ciiva.com/16435/dcrcwe3-16435934.pdf?src-supplier=Future+Electronics; ComponentLink8URL: https://datasheet.ciiva.com/16435/dcrcwe3-16435934.pdf?src-supplier=Future+Electronics; ComponentLink9Description: https://datasheet.ciiva.com/17204/dcrcwe3-17204398.pdf?src-supplier=Avnet+Europe; ComponentLink9URL: https://datasheet.ciiva.com/17204/dcrcwe3-17204398.pdf?src-supplier=Avnet+Europe; Construction: Rectangular; Contact Plating: Tin; Depth: 1.25mm; Features: Automotive AEC-Q200; Height: 0.02inch; Lead Free: Lead Free; Length: 2mm; Library Name: CW_Schlib.SchLib; Manufacturer Part Number: CRCW08051K00JNEA; Max Operating Temperature: 155°C; Military Standard: Not; Min Operating Temperature: -55°C; Mount: Surface Mount; Number of Pins: 2; Number of Terminations: 2; Package Quantity: 1; Packaging: Tape and Reel; Power Rating: 125mW; Pricing 1: 1=0,10000, 10=0,04200, 100=0,01700, 1000=0,00762, 2500=0,00661 (USD); Radiation Hardening: No; REACH SVHC: Unknown; Resistance: 1kR; Resistance Tolerance: 5%; Resistor Type: Thick Film; RoHS: Yes; RoHS Compliant: Yes; Series: CRCW; Size Code: 0805; Stock 1: 2818; Supplier 1: Digi-Key; Supplier Part Number 1: 541-1.0KACT-ND; Termination: SMD/SMT; Tolerance: 5%; Voltage Rating (DC): 150V; Weight: 0.000194oz; Width: 1.25mm
R4 = CRCW0805100KJNEB: Res Thick Film 0805 100K Ohm 5% 1/8W 200ppm/C Molded SMD SMD Paper T/R; Manufacturer: Vishay Dale; Max Power Dissipation: 125mW; Temperature Coefficient: 200ppm/°C; Voltage Rating: 150V; Case Code (Imperial): 0805; Case Code (Metric): 2012; Case/Package: 0805; Category: Chip SMD Resistors; Component Kind: Standard; ComponentLink1Description: https://datasheet.ciiva.com/5543/dcrcwe3-109170-5543783.pdf?src-supplier=Mouser; ComponentLink1URL: https://datasheet.ciiva.com/5543/dcrcwe3-109170-5543783.pdf?src-supplier=Mouser; ComponentLink2Description: https://datasheet.ciiva.com/8982/dcrcwe3-8982399.pdf?src-supplier=Avnet; ComponentLink2URL: https://datasheet.ciiva.com/8982/dcrcwe3-8982399.pdf?src-supplier=Avnet; Contact Plating: Tin; Features: Automotive AEC-Q200; Height: 0.02inch; Lead Free: Lead Free; Length: 0.079inch; Library Name: CW_Schlib.SchLib; Manufacturer Part Number: CRCW0805100KJNEB; Max Operating Temperature: 155°C; Min Operating Temperature: -55°C; Number of Pins: 2; Number of Terminations: 2; Package Quantity: 10000; Packaging: Tape and Reel; Power Rating: 125mW; Pricing 1: 1=0,10000, 10=0,04200, 100=0,01700, 1000=0,00762, 2500=0,00661, 5000=0,00546 (USD); Radiation Hardening: No; REACH SVHC: Unknown; Resistance: 100kR; Resistance Tolerance: 5%; Resistor Type: Thick Film; RoHS: Yes; RoHS Compliant: Yes; Series: CRCW; Stock 1: 14812; Supplier 1: Digi-Key; Supplier Part Number 1: 541-CRCW0805100KJNEBCT-ND; Tolerance: 5%; Voltage Rating (DC): 150V; Weight: 0.000194oz; Width: 0.049inch
R5 = 3313J-1-103E: BOURNS 3313J-1-103E             TRIMMER, 10KOHM, 125mW, SMD; Insulation Resistance: 100MR; Number of Turns: 1; Resistance Tolerance: 20%; Temperature Coefficient: 100ppm/°C; Voltage Rating (DC): 500V; Category: Variable Resistors and Potentiometers; Color: Natural; Component Kind: Standard; ComponentLink1Description: https://datasheet.ciiva.com/6197/1719390-6197392.pdf?src-supplier=Farnell; ComponentLink1URL: https://datasheet.ciiva.com/6197/1719390-6197392.pdf?src-supplier=Farnell; ComponentLink2Description: https://datasheet.ciiva.com/11831/0900766b80dbb89c-11831555.pdf?src-supplier=RSComponents; ComponentLink2URL: https://datasheet.ciiva.com/11831/0900766b80dbb89c-11831555.pdf?src-supplier=RSComponents; ComponentLink3Description: https://datasheet.ciiva.com/2156/3313-2156354.pdf?src-supplier=Digi-Key; ComponentLink3URL: https://datasheet.ciiva.com/2156/3313-2156354.pdf?src-supplier=Digi-Key; ComponentLink4Description: https://datasheet.ciiva.com/2156/3313-2156355.pdf?src-supplier=Digi-Key; ComponentLink4URL: https://datasheet.ciiva.com/2156/3313-2156355.pdf?src-supplier=Digi-Key; ComponentLink5Description: https://datasheet.ciiva.com/2156/3313-2156356.pdf?src-supplier=Digi-Key; ComponentLink5URL: https://datasheet.ciiva.com/2156/3313-2156356.pdf?src-supplier=Digi-Key; ComponentLink6Description: https://datasheet.ciiva.com/43573/1157501-43573718.pdf?src-supplier=Jameco; ComponentLink6URL: https://datasheet.ciiva.com/43573/1157501-43573718.pdf?src-supplier=Jameco; ComponentLink7Description: https://datasheet.ciiva.com/28659/1770668-28659191.pdf?src-supplier=Element14; ComponentLink7URL: https://datasheet.ciiva.com/28659/1770668-28659191.pdf?src-supplier=Element14; ComponentLink8Description: https://datasheet.ciiva.com/16812/3313-16812088.pdf?src-supplier=Avnet+Europe; ComponentLink8URL: https://datasheet.ciiva.com/16812/3313-16812088.pdf?src-supplier=Avnet+Europe; ComponentLink9Description: https://datasheet.ciiva.com/7953/1864507-7953225.pdf?src-supplier=Element14; ComponentLink9URL: https://datasheet.ciiva.com/7953/1864507-7953225.pdf?src-supplier=Element14; ComponentLink10Description: https://datasheet.ciiva.com/16715/70153423-16715693.pdf?src-supplier=Allied+Electronics; ComponentLink10URL: https://datasheet.ciiva.com/16715/70153423-16715693.pdf?src-supplier=Allied+Electronics; Depth: 3.5mm; Height: 2.2mm; Lead Free: Lead Free; Length: 3.2mm; Manufacturer: Bourns; Manufacturer Part Number: 3313J-1-103E; Max Operating Temperature: 125°C; Max Power Dissipation: 125mW; Military Standard: Not; Min Operating Temperature: -55°C; Mount: Surface Mount; Package Quantity: 1000; Packaging: Tape and Reel; Power Rating: 125mW; Pricing 1: 1=1,82000, 10=1,59500, 25=1,43000, 50=1,37500, 100=1,32000, 250=1,26500, 500=1,04500 (USD); Radiation Hardening: No; REACH SVHC: No SVHC; Resistance: 10kR; Resistor Type: Cermet; RoHS: Yes; RoHS Compliant: Yes; Series: Trimpot® 3313 - Sealed; Stock 1: 968; Supplier 1: Digi-Key; Supplier Part Number 1: 3313J-103ECT-ND; Taper: Linear; Technology: CERMET; Termination: J-Hook; Tolerance: 20%; Type: Potentiometer; Weight: 283mg; Width: 0.126inch; Library Name: CW_Schlib.SchLib
R6 R9 R10 = CRCW08052K40JNEA: Resistor,Metal Glaze,2.4KOhms,150WV,5+/-% Tol,-200,200ppm-TC,0805-Case ;RoHS Compliant: Yes; Manufacturer: Vishay Dale; Max Power Dissipation: 125mW; Resistance Tolerance: 5%; Temperature Coefficient: 200ppm/°C; Voltage Rating: 150V; Case Code (Imperial): 0805; Case Code (Metric): 2012; Case/Package: 0805; Category: Chip SMD Resistors; Component Kind: Standard; ComponentLink1Description: https://datasheet.ciiva.com/2525/dcrcwe3-2525095.pdf?src-supplier=Digi-Key; ComponentLink1URL: https://datasheet.ciiva.com/2525/dcrcwe3-2525095.pdf?src-supplier=Digi-Key; ComponentLink2Description: https://datasheet.ciiva.com/2525/dcrcwe3-2525096.pdf?src-supplier=Digi-Key; ComponentLink2URL: https://datasheet.ciiva.com/2525/dcrcwe3-2525096.pdf?src-supplier=Digi-Key; ComponentLink3Description: https://datasheet.ciiva.com/2525/dcrcwe3-2525097.pdf?src-supplier=Digi-Key; ComponentLink3URL: https://datasheet.ciiva.com/2525/dcrcwe3-2525097.pdf?src-supplier=Digi-Key; ComponentLink4Description: https://datasheet.ciiva.com/3258/dcrcwe3-3258442.pdf?src-supplier=Avnet; ComponentLink4URL: https://datasheet.ciiva.com/3258/dcrcwe3-3258442.pdf?src-supplier=Avnet; ComponentLink5Description: https://datasheet.ciiva.com/3258/dcrcwe3-3258443.pdf?src-supplier=Avnet; ComponentLink5URL: https://datasheet.ciiva.com/3258/dcrcwe3-3258443.pdf?src-supplier=Avnet; ComponentLink6Description: https://datasheet.ciiva.com/16392/dcrcw-16392315.pdf?src-supplier=Future+Electronics; ComponentLink6URL: https://datasheet.ciiva.com/16392/dcrcw-16392315.pdf?src-supplier=Future+Electronics; Construction: Rectangular; Contact Plating: Tin; Depth: 1.25mm; Features: Automotive AEC-Q200; Height: 0.02inch; Lead Free: Lead Free; Length: 2mm; Library Name: CW_Schlib.SchLib; Manufacturer Part Number: CRCW08052K40JNEA; Max Operating Temperature: 155°C; Military Standard: Not; Min Operating Temperature: -55°C; Number of Pins: 2; Number of Terminations: 2; Package Quantity: 1; Packaging: Tape and Reel; Power Rating: 125mW; Pricing 1: 1=0,10000, 10=0,04200, 100=0,01700, 1000=0,00762, 2500=0,00661 (USD); Radiation Hardening: No; REACH SVHC: Unknown; Resistance: 2.4kR; Resistor Type: Thick Film; RoHS: Yes; RoHS Compliant: Yes; Series: CRCW; Size Code: 0805; Stock 1: 1280; Supplier 1: Digi-Key; Supplier Part Number 1: 541-2.4KACT-ND; Termination: SMD/SMT; Tolerance: 5%; Voltage Rating (DC): 150V; Weight: 0.000194oz; Width: 1.25mm
R7 R8 = CRCW0805220KJNEA: RES SMD 220K OHM 5% 1/8W 0805; Manufacturer: Vishay Dale; Max Power Dissipation: 125mW; Temperature Coefficient: 200ppm/°C; Voltage Rating: 150V; Case Code (Imperial): 0805; Case Code (Metric): 2012; Case/Package: 0805; Category: Chip SMD Resistors; Component Kind: Standard; ComponentLink1Description: https://datasheet.ciiva.com/2464/dcrcwe3-2464864.pdf?src-supplier=Digi-Key; ComponentLink1URL: https://datasheet.ciiva.com/2464/dcrcwe3-2464864.pdf?src-supplier=Digi-Key; ComponentLink2Description: https://datasheet.ciiva.com/2464/dcrcwe3-2464865.pdf?src-supplier=Digi-Key; ComponentLink2URL: https://datasheet.ciiva.com/2464/dcrcwe3-2464865.pdf?src-supplier=Digi-Key; ComponentLink3Description: https://datasheet.ciiva.com/2464/dcrcwe3-2464866.pdf?src-supplier=Digi-Key; ComponentLink3URL: https://datasheet.ciiva.com/2464/dcrcwe3-2464866.pdf?src-supplier=Digi-Key; ComponentLink4Description: https://datasheet.ciiva.com/3258/dcrcwe3-3258325.pdf?src-supplier=Avnet; ComponentLink4URL: https://datasheet.ciiva.com/3258/dcrcwe3-3258325.pdf?src-supplier=Avnet; ComponentLink5Description: https://datasheet.ciiva.com/17166/dcrcwe3-17166711.pdf?src-supplier=Avnet+Europe; ComponentLink5URL: https://datasheet.ciiva.com/17166/dcrcwe3-17166711.pdf?src-supplier=Avnet+Europe; ComponentLink6Description: https://datasheet.ciiva.com/28606/1747939-28606759.pdf?src-supplier=Element14; ComponentLink6URL: https://datasheet.ciiva.com/28606/1747939-28606759.pdf?src-supplier=Element14; ComponentLink7Description: https://datasheet.ciiva.com/16498/dcrcw-16498286.pdf?src-supplier=Future+Electronics; ComponentLink7URL: https://datasheet.ciiva.com/16498/dcrcw-16498286.pdf?src-supplier=Future+Electronics; Construction: Rectangular; Contact Plating: Tin; Depth: 1.25mm; Features: Automotive AEC-Q200; Height: 0.02inch; Lead Free: Lead Free; Length: 2mm; Library Name: CW_Schlib.SchLib; Manufacturer Part Number: CRCW0805220KJNEA; Max Operating Temperature: 155°C; Military Standard: Not; Min Operating Temperature: -55°C; Mount: Surface Mount; Number of Terminations: 2; Package Quantity: 1; Packaging: Tape and Reel; Power Rating: 125mW; Pricing 1: 1=0,10000, 10=0,04200, 100=0,01700, 1000=0,00762, 2500=0,00661 (USD); Radiation Hardening: No; REACH SVHC: Unknown; Resistance: 220kR; Resistance Tolerance: 5%; Resistor Type: Thick Film; RoHS: Yes; RoHS Compliant: Yes; Series: CRCW; Size Code: 0805; Stock 1: 1120; Supplier 1: Digi-Key; Supplier Part Number 1: 541-220KACT-ND; Termination: SMD/SMT; Tolerance: 5%; Voltage Rating (DC): 150V; Weight: 0.000194oz; Width: 0.049inch
R12 = ERA-6AEB101V: SISTOR, METAL FILM, 100 OHM, 125mW, 0.1%; Product Range:PANASONIC - ERA Series; Resistance:100ohm; Power Rating:125mW; Resistance Tolerance:± 0.1%; Resistor Case Style:0805 ^2012 Metric]; Resistor Element Type:Thin Film; MSL:-; Temperature Coefficient: 25ppm/°C; Voltage Rating: 100V; Case Code (Imperial): 0805; Case Code (Metric): 2012; Case/Package: 0805; Category: Chip SMD Resistors; Component Kind: Standard; ComponentLink1Description: https://datasheet.ciiva.com/11810/0900766b80beefcc-11810733.pdf?src-supplier=RSComponents; ComponentLink1URL: https://datasheet.ciiva.com/11810/0900766b80beefcc-11810733.pdf?src-supplier=RSComponents; ComponentLink2Description: https://datasheet.ciiva.com/29184/452698-da-01-en-widerstand-0805-era-29184051.pdf?src-supplier=Conrad; ComponentLink2URL: https://datasheet.ciiva.com/29184/452698-da-01-en-widerstand-0805-era-29184051.pdf?src-supplier=Conrad; ComponentLink3Description: https://datasheet.ciiva.com/16774/70068849-16774677.pdf?src-supplier=Allied+Electronics; ComponentLink3URL: https://datasheet.ciiva.com/16774/70068849-16774677.pdf?src-supplier=Allied+Electronics; ComponentLink4Description: https://datasheet.ciiva.com/22758/485442-22758149.pdf?src-supplier=Farnell; ComponentLink4URL: https://datasheet.ciiva.com/22758/485442-22758149.pdf?src-supplier=Farnell; ComponentLink5Description: https://datasheet.ciiva.com/46438/485442-46438213.pdf?src-supplier=Element14; ComponentLink5URL: https://datasheet.ciiva.com/46438/485442-46438213.pdf?src-supplier=Element14; ComponentLink6Description: https://datasheet.ciiva.com/46438/485442-46438214.pdf?src-supplier=Element14; ComponentLink6URL: https://datasheet.ciiva.com/46438/485442-46438214.pdf?src-supplier=Element14; ComponentLink7Description: https://datasheet.ciiva.com/16770/70068849-16770007.pdf?src-supplier=Allied+Electronics; ComponentLink7URL: https://datasheet.ciiva.com/16770/70068849-16770007.pdf?src-supplier=Allied+Electronics; ComponentLink8Description: https://datasheet.ciiva.com/30140/54aoa0000ce26-30140696.pdf?src-supplier=Onlinecomponents.com; ComponentLink8URL: https://datasheet.ciiva.com/30140/54aoa0000ce26-30140696.pdf?src-supplier=Onlinecomponents.com; ComponentLink9Description: https://datasheet.ciiva.com/17207/aoa0000ce26-17207961.pdf?src-supplier=Avnet+Europe; ComponentLink9URL: https://datasheet.ciiva.com/17207/aoa0000ce26-17207961.pdf?src-supplier=Avnet+Europe; ComponentLink10Description: https://datasheet.ciiva.com/24072/aoa0000ce26-32660-24072217.pdf?src-supplier=Mouser; ComponentLink10URL: https://datasheet.ciiva.com/24072/aoa0000ce26-32660-24072217.pdf?src-supplier=Mouser; ComponentLink11Description: https://datasheet.ciiva.com/28513/485442-28513850.pdf?src-supplier=Element14; ComponentLink11URL: https://datasheet.ciiva.com/28513/485442-28513850.pdf?src-supplier=Element14; ComponentLink12Description: https://datasheet.ciiva.com/48536/era-eng-tds-48536747.pdf?src-supplier=Distrelec; ComponentLink12URL: https://datasheet.ciiva.com/48536/era-eng-tds-48536747.pdf?src-supplier=Distrelec; ComponentLink13Description: https://datasheet.ciiva.com/16396/aoa0000ce26-16396828.pdf?src-supplier=Future+Electronics; ComponentLink13URL: https://datasheet.ciiva.com/16396/aoa0000ce26-16396828.pdf?src-supplier=Future+Electronics; ComponentLink14Description: https://datasheet.ciiva.com/9186/aoa0000ce26-9186749.pdf?src-supplier=Avnet; ComponentLink14URL: https://datasheet.ciiva.com/9186/aoa0000ce26-9186749.pdf?src-supplier=Avnet; Construction: Rectangular; Contact Plating: Tin; Depth: 1.25mm; Features: Automotive AEC-Q200; Height: 0.5mm; Lead Free: Lead Free; Length: 2mm; Manufacturer: Panasonic; Manufacturer Part Number: ERA-6AEB101V; Max Operating Temperature: 155°C; Max Power Dissipation: 125mW; Military Standard: Not; Min Operating Temperature: -55°C; Mount: Surface Mount; Number of Pins: 2; Number of Terminations: 2; Package Quantity: 1; Packaging: Tape and Reel; Power Rating: 125mW; Pricing 1: 1=0,31000, 10=0,26200, 100=0,10230, 1000=0,04284, 2500=0,03927 (USD); Radiation Hardening: No; REACH SVHC: No SVHC; Resistance: 100R; Resistance Tolerance: 0.1%; Resistor Type: Thin Film; RoHS: Yes; RoHS Compliant: Yes; Series: ERA-6A; Size Code: 0805; Stock 1: 69; Supplier 1: Digi-Key; Supplier Part Number 1: P100DACT-ND; Technology: THIN FILM; Termination: SMD/SMT; Tolerance: 0.1%; Voltage Rating (DC): 100V; Width: 1.25mm; Library Name: CW_Schlib.SchLib
VT1-VT6 = BC639: TRANS NPN 80V 1A TO-92; Manufacturer: ON Semiconductor / Fairchild; Collector Emitter Saturation Voltage: 500mV; Element Configuration: Single; Emitter Base Voltage (VEBO): 5V; Frequency: 100MHz; Gain Bandwidth Product: 200MHz; hFE Min: 40; Max Power Dissipation: 625mW; Number of Elements: 1; Power Dissipation: 1W; Case/Package: TO-92; Category: BJTs; Collector Base Voltage (VCBO): 80V; Collector Emitter Breakdown Voltage: 80V; Collector Emitter Voltage (VCEO): 80V; Collector-emitter Voltage-Max: 500mV; Component Kind: Standard; ComponentLink1Description: https://datasheet.ciiva.com/982/bc639-982480.pdf?src-supplier=Digi-Key; ComponentLink1URL: https://datasheet.ciiva.com/982/bc639-982480.pdf?src-supplier=Digi-Key; ComponentLink2Description: https://datasheet.ciiva.com/986/bc635-637-639rev5-986450.pdf?src-supplier=Digi-Key; ComponentLink2URL: https://datasheet.ciiva.com/986/bc635-637-639rev5-986450.pdf?src-supplier=Digi-Key; ComponentLink3Description: https://datasheet.ciiva.com/17179/bc63916-17179413.pdf?src-supplier=Future+Electronics; ComponentLink3URL: https://datasheet.ciiva.com/17179/bc63916-17179413.pdf?src-supplier=Future+Electronics; ComponentLink4Description: https://datasheet.ciiva.com/1195/5224-1195640.pdf?src-supplier=Element14; ComponentLink4URL: https://datasheet.ciiva.com/1195/5224-1195640.pdf?src-supplier=Element14; ComponentLink5Description: https://datasheet.ciiva.com/1195/5224-1195641.pdf?src-supplier=Element14; ComponentLink5URL: https://datasheet.ciiva.com/1195/5224-1195641.pdf?src-supplier=Element14; ComponentLink6Description: https://datasheet.ciiva.com/1217/5224-1217209.pdf?src-supplier=Farnell; ComponentLink6URL: https://datasheet.ciiva.com/1217/5224-1217209.pdf?src-supplier=Farnell; Contact Plating: Copper,Tin,Silver; Current Rating: 1A; Lead Free: Lead Free; Manufacturer Part Number: BC639; Max Breakdown Voltage: 80V; Max Collector Current: 1A; Max Operating Temperature: 150°C; Min Operating Temperature: -55°C; Mount: Through Hole; Number of Pins: 3; Package Quantity: 5000; Packaging: Bulk; Polarity: NPN; Pricing 1: 5342=0,06646, 5400=0,06552, 11000=0,06365, 27000=0,06224, 54000=0,05990, 270000=0,05850, 540000=0,05710 (USD); REACH SVHC: No SVHC; RoHS: No; RoHS Compliant: No; Series: -; Stock 1: 10708; Supplier 1: Avnet; Supplier Part Number 1: BC639; Termination: Through Hole; Transition Frequency: 200MHz; Voltage Rating (DC): 80V; Weight: 0.201g; Library Name: CW_Schlib.SchLib
X1 = KLDX-0202-A: KYCON - KLDX-0202-A - DC Power Connector, Jack, 3.5 A, 2 mm, PCB Mount, Through Hole; Current Rating: 3.5A; Gender: Female; Insulation Resistance: 100MR; Number of Contacts: 2; Voltage Rating: 24V; Category: Connectors; Color: Black; Component Kind: Standard; ComponentLink1Description: http://www.mouser.com/ds/2/222/KLDX_0202_LT-334784.pdf?src-supplier=Mouser; ComponentLink1URL: http://www.mouser.com/ds/2/222/KLDX_0202_LT-334784.pdf?src-supplier=Mouser; ComponentLink2Description: http://domino2.kycon.com/catalog_PDF/KLDX_0202.pdf?src-supplier=Avnet; ComponentLink2URL: http://domino2.kycon.com/catalog_PDF/KLDX_0202.pdf?src-supplier=Avnet; ComponentLink3Description: http://domino2.kycon.com/catalog_PDF/KLDX_0202.pdf?src-supplier=Avnet+Europe; ComponentLink3URL: http://domino2.kycon.com/catalog_PDF/KLDX_0202.pdf?src-supplier=Avnet+Europe; Connector Type: Power; Contact Gender: Female; Contact Material: Brass; Contact Plating: Tin; Flammability Rating: UL94 V-0; Housing Material: PBT; Manufacturer: Kycon; Manufacturer Part Number: KLDX-0202-A; Max Operating Temperature: 85°C; Min Operating Temperature: -25°C; Mount: Through Hole; Orientation: Right Angle; Package Quantity: 500; Packaging: Bag; Pricing 1: 1=0,54000, 10=0,50600, 25=0,45560, 50=0,40480, 100=0,38790, 250=0,35420, 500=0,33734, 1000=0,27831, 2500=0,25300 (USD); Radiation Hardening: No; RoHS: Yes; RoHS Compliant: Yes; Series: KLDX; Stock 1: 10383; Supplier 1: Digi-Key; Supplier Part Number 1: 2092-KLDX-0202-A-ND; Termination: Solder; Voltage Rating (DC): 24V; Library Name: CW_Schlib.SchLib
X2 = 43650-0229: MOLEX - 43650-0229 - Wire-To-Board Connector, 3 mm, 2 Contacts, Header, Micro-Fit 3.0 43650 Series, Through Hole, 1 Rows; Current Rating: 5A; Gender: Male; Glow Wire Compliant: Compliant; Number of Circuits: 2; Pitch: 3mm; Voltage Rating: 600V; Category: Headers and Wire Housings; Component Kind: Standard; ComponentLink1Description: https://datasheet.ciiva.com/13564/0436500229-pcb-headers-148697-13564517.pdf?src-supplier=Mouser; ComponentLink1URL: https://datasheet.ciiva.com/13564/0436500229-pcb-headers-148697-13564517.pdf?src-supplier=Mouser; ComponentLink2Description: https://datasheet.ciiva.com/16654/0436500229-pcb-headers-16654485.pdf?src-supplier=Avnet+Europe; ComponentLink2URL: https://datasheet.ciiva.com/16654/0436500229-pcb-headers-16654485.pdf?src-supplier=Avnet+Europe; Contact Material: Brass; Contact Plating: Gold; Halogen Free: Low Halogen; Housing Material: Thermoplastic; Manufacturer: Molex; Manufacturer Part Number: 43650-0229; Mount: Through Hole; Number of Contacts: 2; Number of Positions: 2; Number of Rows: 1; Orientation: Vertical; Package Quantity: 3264; Packaging: Tray; Pricing 1: 1=1,21000, 10=1,00100, 440=0,83407, 3264=0,60469, 6528=0,58384, 13056=0,54214 (USD); Radiation Hardening: No; RoHS: Yes; RoHS Compliant: Yes; Series: 43650; Stock 1: 5963; Supplier 1: Digi-Key; Supplier Part Number 1: WM10662-ND; Termination: Through Hole; Library Name: CW_Schlib.SchLib
